# Altium schematic sheet Main.SchDoc
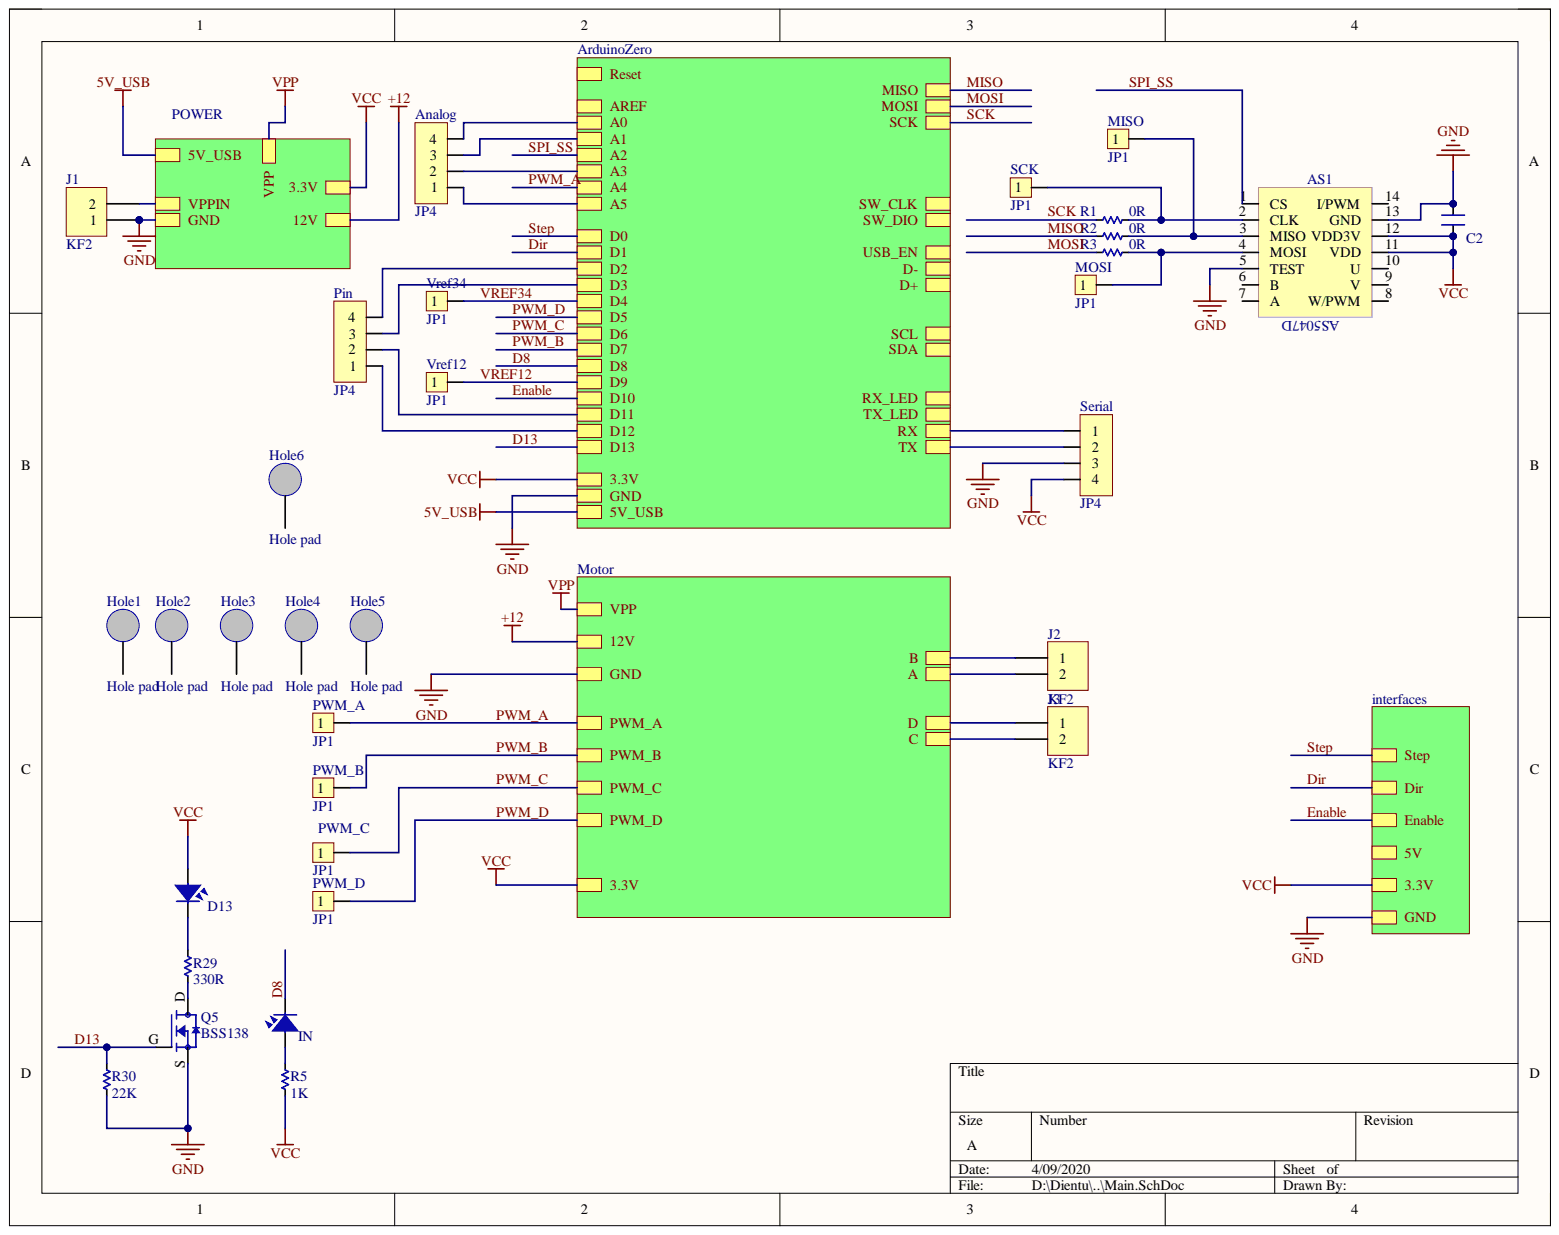
[diagram p0: Main.SchDoc]

## Parts
Analog  JP4  [JP4-C1T]
AS1  AS5047D  Current_Str: A  [AS5047D_Module]
C2  CN  [CN-SMD-0603_big]  (value not drawn)
D13  LED  [LED-0805-Blue]  (value not drawn)
Hole1  Hole pad  [HolePad]
Hole2  Hole pad  [HolePad]
Hole3  Hole pad  [HolePad]
Hole4  Hole pad  [HolePad]
Hole5  Hole pad  [HolePad]
Hole6  Hole pad  [HolePad]
IN  LED  [LED-0805-Blue]  (value not drawn)
J1  KF2  [KF2-5.08T]
J2  KF2  [KF2-5.08T]
J3  KF2  [KF2-5.08T]
MISO  JP1  [JP1-D1T]
MOSI  JP1  [JP1-D1T]
Pin  JP4  [JP4-C1T]
PWM_A  JP1  [JP1-D1T]
PWM_B  JP1  [JP1-D1T]
PWM_C  JP1  [JP1-D1T]
PWM_D  JP1  [JP1-D1T]
Q5  BSS138  [SOT23-bss138]
R1  0R  [RES-SMD-0603_BIG]
R2  0R  [RES-SMD-0603_BIG]
R3  0R  [RES-SMD-0603_BIG]
R5  1K  [RES-SMD-0603_BIG]
R29  330R  [RES-SMD-0603_BIG]
R30  22K  [RES-SMD-0603_BIG]
SCK  JP1  [JP1-D1T]
Serial  JP4  [JP4-C1T]
Vref12  JP1  [JP1-D1T]
Vref34  JP1  [JP1-D1T]

## Nets
GND: AS1.5(TEST) AS1.13(GND) C2.2 J1.1 Q5.S R30.1 Serial.3
VCC: AS1.11(VDD) AS1.12(VDD3V) C2.1 D13.1 R5.2 Serial.4
D8: IN.2
D13: Q5.G R30.2
MISO: R2.2
MOSI: R3.2
PWM_A: PWM_A.1
PWM_B: PWM_B.1
PWM_C: PWM_C.1
PWM_D: PWM_D.1
SCK: R1.2
SPI_SS: AS1.1(CS)
VREF12: Vref12.1
VREF34: Vref34.1
NetAnalog_1: Analog.1
NetAnalog_2: Analog.2
NetAnalog_3: Analog.3
NetAnalog_4: Analog.4
NetAS1_2: AS1.2(CLK) R1.1 SCK.1
NetAS1_3: AS1.3(MISO) MISO.1 R2.1
NetAS1_4: AS1.4(MOSI) MOSI.1 R3.1
NetAS1_6: AS1.6(B)
NetAS1_7: AS1.7(A)
NetAS1_8: AS1.8(W/PWM)
NetAS1_9: AS1.9(V)
NetAS1_10: AS1.10(U)
NetAS1_14: AS1.14(I/PWM)
NetD13_2: D13.2 R29.1
NetHole1_1: Hole1.1
NetHole2_1: Hole2.1
NetHole3_1: Hole3.1
NetHole4_1: Hole4.1
NetHole5_1: Hole5.1
NetHole6_1: Hole6.1
NetIN_1: IN.1 R5.1
NetJ1_2: J1.2
NetJ2_1: J2.1
NetJ2_2: J2.2
NetJ3_1: J3.1
NetJ3_2: J3.2
NetPin_1: Pin.1
NetPin_2: Pin.2
NetPin_3: Pin.3
NetPin_4: Pin.4
NetQ5_D: Q5.D R29.2
NetSerial_1: Serial.1
NetSerial_2: Serial.2
5V:
5V_USB:
+12:
AREF:
D+:
D-:
Dir:
Enable:
Reset:
RX_LED:
SCL:
SDA:
Step:
SW_CLK:
SW_DIO:
TX_LED:
USB_EN:
VPP:

## Library data of the parts
Analog Pin Serial = JP4: WR-PHD 2.54 mm Angled Socket Header; Manufacturer: W¨¹rth Elektronik eiSos GmbH; partNo: 613004143121; ComponentLink1 Description: Manufacturer; ComponentLink2 Description: Datasheet; NoPins: 04; Component Kind: Standard; ComponentLink1URL: http://www.we-online.com; ComponentLink2URL: http://katalog.we-online.de/em/datasheet/6130xx143121.pdf; Created Date: 29 March 2013; Library Name: LIBRARY.IntLib; Created by: Karrer Zheng
AS1 = (no symbol name): Manufacture_Parts: :AS5047D; ID: 37; Misfit Price: 0; Vendor: unknown; Voltage_Str: 0V; Watts_Str: 0W; Company_Part_GUID: altiumdb5786b44c08eb91.84503477; Component: AS5047D; Component Kind: Standard; ComponentLink1Description: unknown; ComponentLink2Description: Datasheet; Manufacturer_Part_Number: AS5047D; Price: 0; Voltage: 0; Watts: 0; Library Name: nhan.SchLib
C2 = CN: Value: 33pF; Component Kind: Standard; Library Name: nhan.SchLib
D13 IN = LED SMD: Value: LED 3 - 5 ly 2 Colour; Component Kind: Standard; Library Name: LIBRARY.IntLib
J1-J3 = KF2: Manufacturer: Würth Elektronik eiSos GmbH; partNo: 691103110002; ComponentLink1 Description: Manufacturer; ComponentLink2 Description: Datasheet; NoPins: 2; Component Kind: Standard; ComponentLink1URL: http://www.we-online.com; ComponentLink2URL: http://katalog.we-online.de/em/datasheet/6911031100xx.pdf; Created Date: 29 October 2013; Created by: Karrer Zheng; Library Name: BanLinhKien.IntLib
MISO MOSI PWM_A PWM_B PWM_C PWM_D SCK Vref12 Vref34 = JP1: WR-PHD 2.54 mm Angled Socket Header; Manufacturer: W¨¹rth Elektronik eiSos GmbH; partNo: 613002143121; ComponentLink1 Description: Manufacturer; ComponentLink2 Description: Datasheet; NoPins: 02; Component Kind: Standard; ComponentLink1URL: http://www.we-online.com; ComponentLink2URL: http://katalog.we-online.de/em/datasheet/6130xx143121.pdf; Created Date: 29 March 2013; Library Name: LIBRARY.IntLib; Created by: Karrer Zheng
Q5 = BSS138: N-Channel 50V 220mA _Ta_ 360mW _Ta_ Surface Mount Die; MF: MICROSS/On Semiconductor; Availability: Unavailable; Component Kind: Standard; MP: BSS138; Package: TO-236-3 Micross; Price: None; SnapEDA_Link: https://www.snapeda.com/parts/BSS138/MICROSS/On%20Semiconductor/view-part/2773844/?ref=snap; Library Name: nhan.SchLib
R1-R3 R5 R29 R30 = R: Component Kind: Standard; Library Name: nhan.SchLib
Hole1-Hole6 = Hole pad: Component Kind: Standard; Library Name: nhan.SchLib
